# Altium schematic sheet NG2094_IR_Flood.SchDoc(NG2094_IR_Flood)
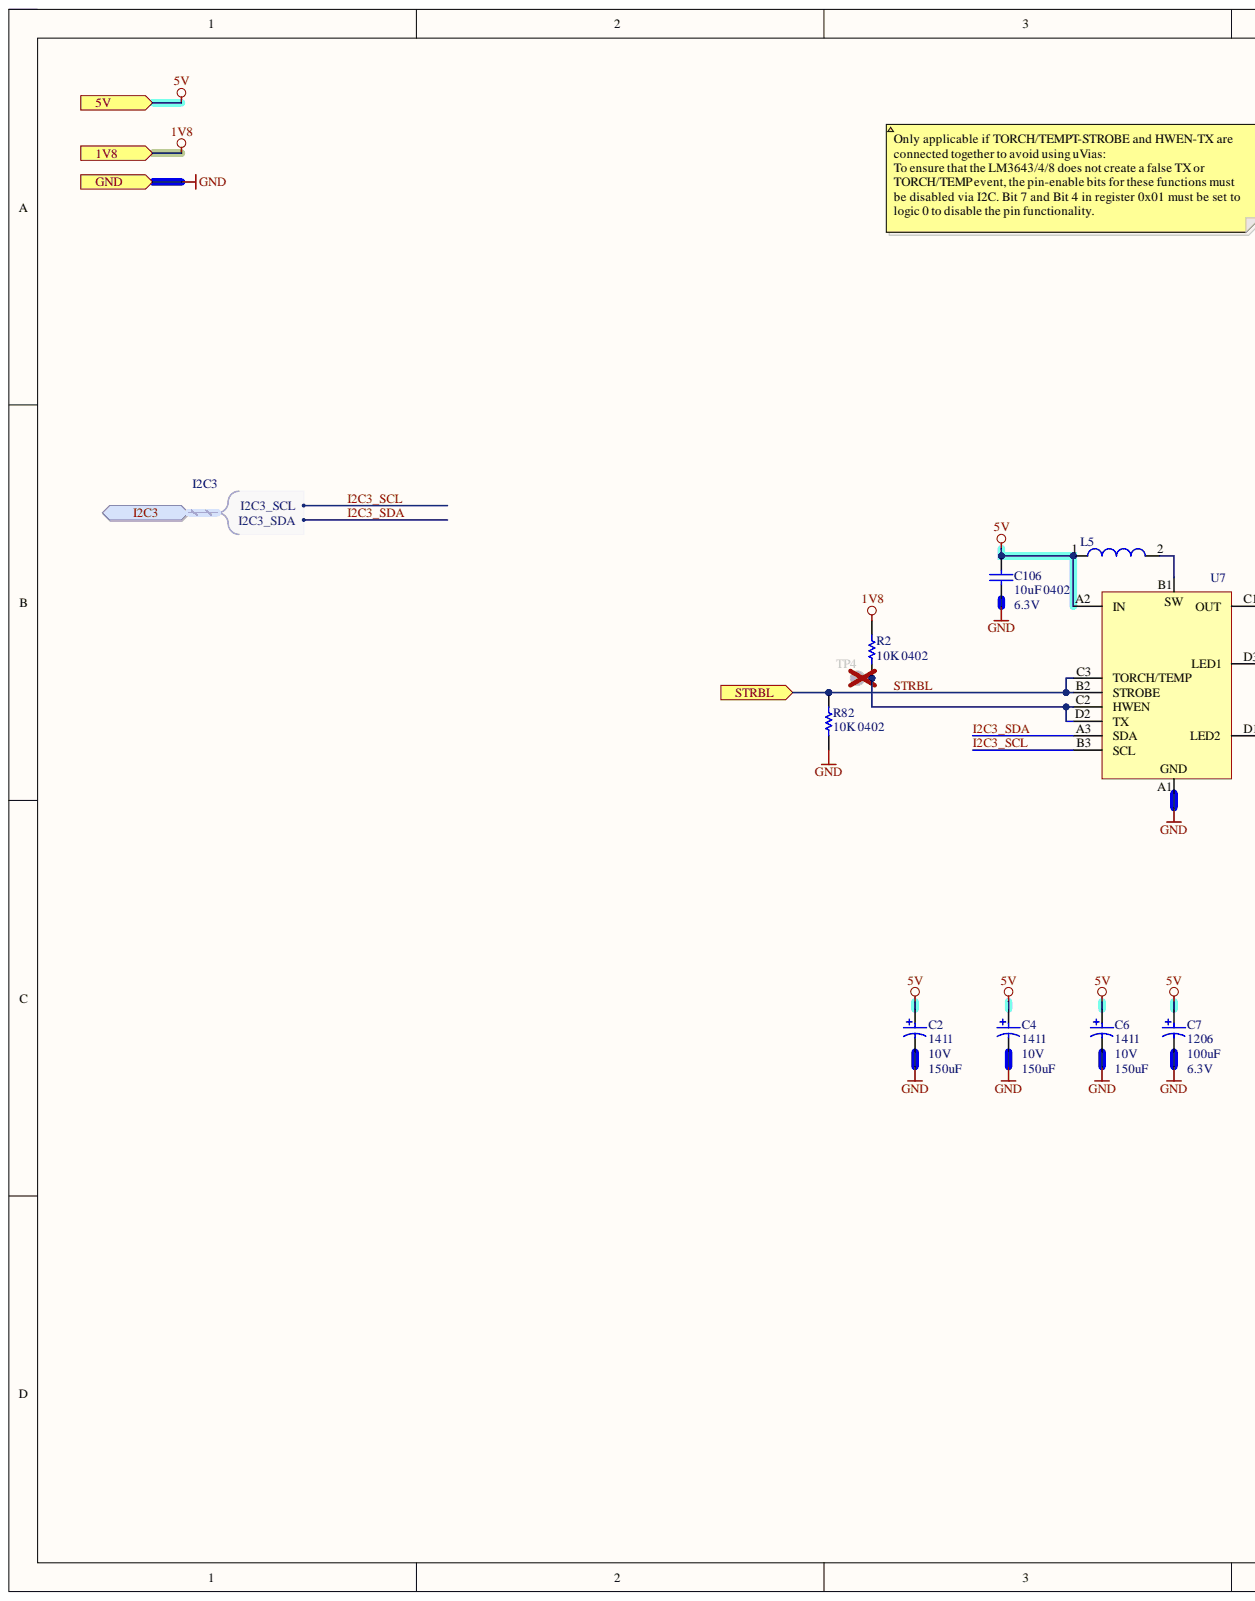
[diagram p0: NG2094_IR_Flood.SchDoc, left]
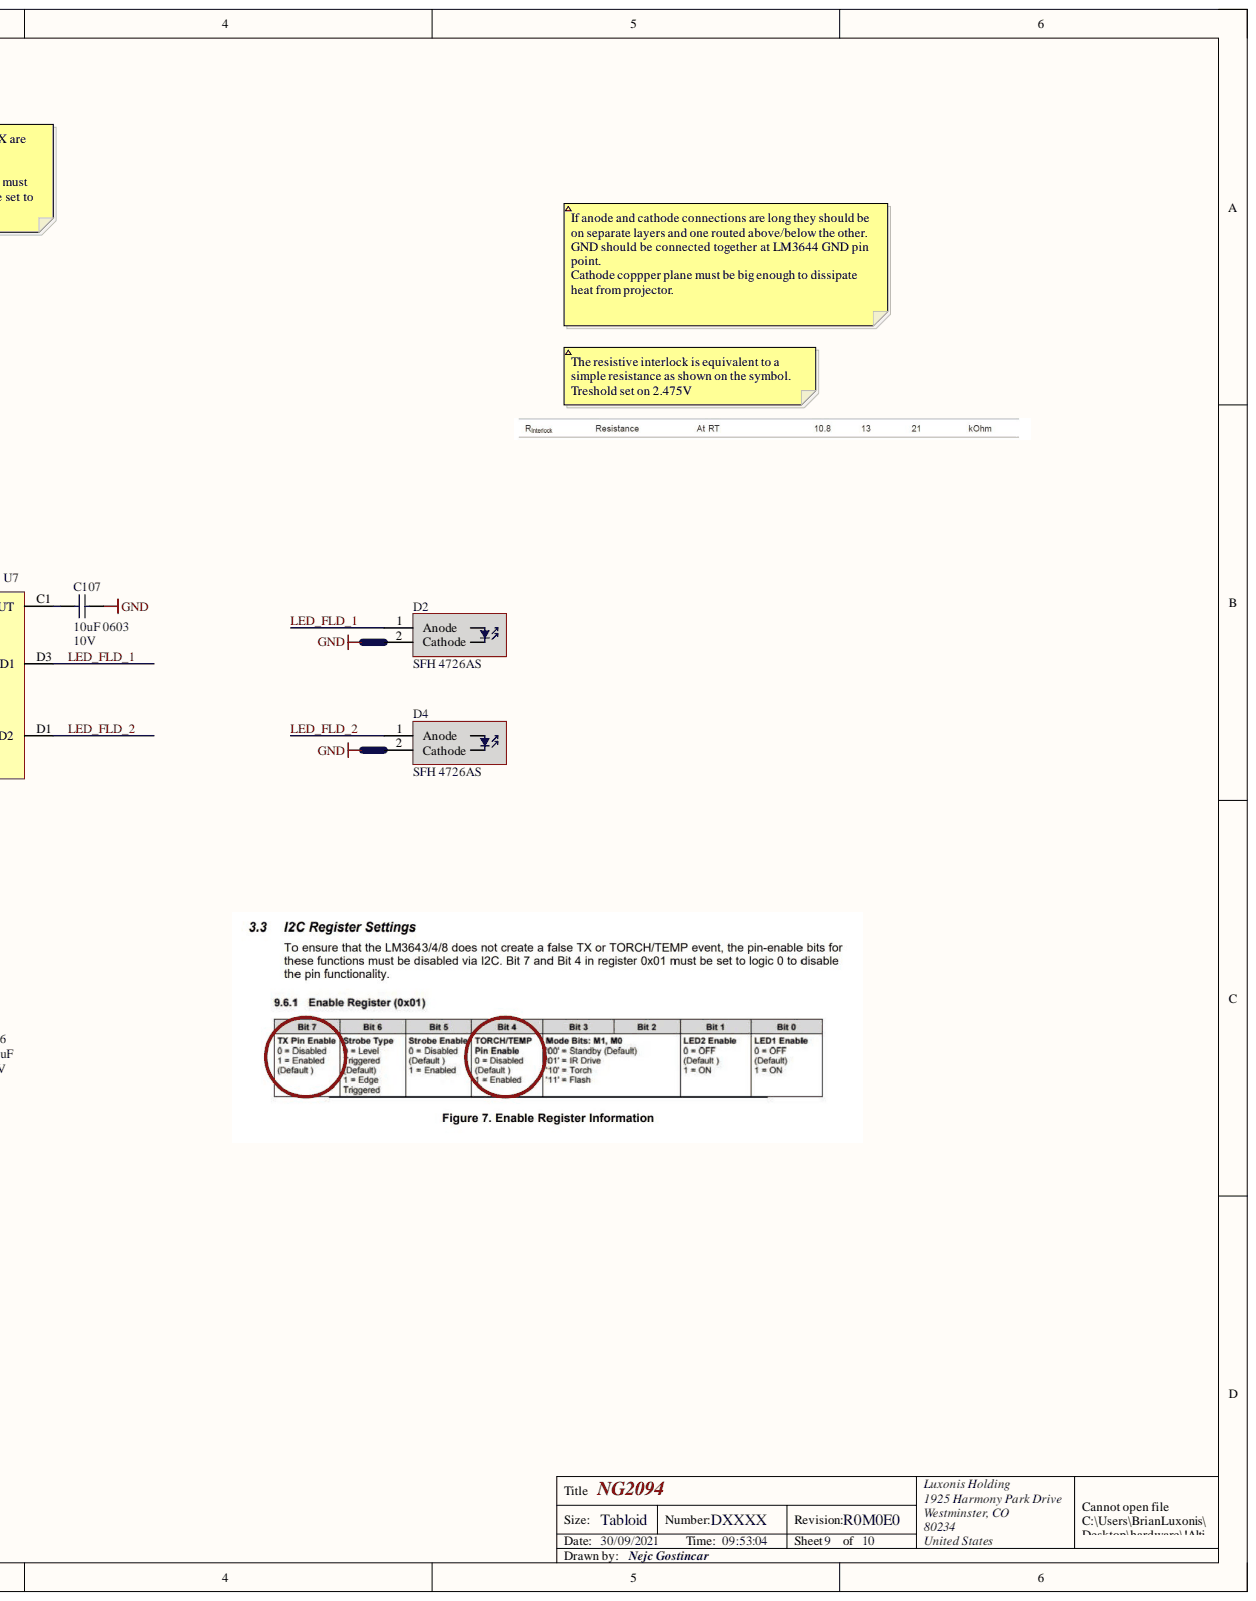
[diagram p1: NG2094_IR_Flood.SchDoc, right]

## Parts
C2  Capacitance: 150uF  Rated DC Voltage (URdc): 10V  Size Code: 1411  [RESTL1210(3225)_N]  (hidden comment: 150uF 1206 10V tantal)
C4  Capacitance: 150uF  Rated DC Voltage (URdc): 10V  Size Code: 1411  [RESTL1210(3225)_N]  (hidden comment: 150uF 1206 10V tantal)
C6  Capacitance: 150uF  Rated DC Voltage (URdc): 10V  Size Code: 1411  [RESTL1210(3225)_N]  (hidden comment: 150uF 1206 10V tantal)
C7  Capacitance: 100uF  Rated DC Voltage (URdc): 6.3V  Size Code: 1206  [RESTL1206(3216)_L]  (hidden comment: 100uF 1206 6V3 tantal)
C106  10uF 0402  Capacitance: 10uF  Voltage Rating: 6.3V  [CAPC0402(1005)70_L]
C107  10uF 0603  Capacitance: 10uF  Case Code (Imperial): 0603  Voltage Rating: 10V  [CAPC0603(1608)100_L]
D2  SFH 4726AS  [SFH 4726AS]
D4  SFH 4726AS  [SFH 4726AS]
L5  1uH, 3.2A, 20%  [DFE252012P-1R0M=P2]  (value not drawn)
R2  10K 0402  Case Code (Imperial): 0402  [RESC0402(1005)_L]
R82  10K 0402  Case Code (Imperial): 0402  [RESC0402(1005)_L]
TP4  TP100_200_NS  [TP100_200_NS]  (value not drawn)  (no BOM)
U7  LM3644YFFR  [LM3644YFFR]  (value not drawn)

## Ports
1V8, 5V, GND, I2C3, I2C3.I2C3_SCL, I2C3.I2C3_SDA, STRBL

## Nets
1V8: R2.2 ; port 1V8
5V: C2.1 C4.1 C6.1 C7.1 C106.2 L5.1 U7.A2(IN) ; port 5V
GND: C2.2 C4.2 C6.2 C7.2 C106.1 C107.2 D2.2 D4.2 R82.1 U7.A1 ; port GND
I2C3_SCL: U7.B3(SCL) ; port I2C3
I2C3_SDA: U7.A3(SDA) ; port I2C3
LED_FLD_1: D2.1 U7.D3(LED1)
LED_FLD_2: D4.1 U7.D1(LED2)
STRBL: R82.2 U7.B2(STROBE) U7.C3(TORCH/TEMP) ; port STRBL
NetC107_1: C107.1 U7.C1(OUT)
NetL5_2: L5.2 U7.B1(SW)
NetR2_1: R2.1 TP4.1 U7.C2(HWEN) U7.D2(TX)

## Library data of the parts
C2 C4 C6 = TLJB157M010R0500: Tantalum Capacitor, Polarized, Tantalum (dry/solid), 10V, 20% +Tol, 20% -Tol, 150uF, Surface Mount, 1210; Manufacturer 1: Kyocera AVX; ESR (Equivalent Series Resistance): 500mR; Leakage Current: 15uA; Ripple Current: 371mA; Capacitor Type: Tantalum; Category: Tantalum Capacitors; Component Kind: Standard; ComponentLink1Description: https://datasheet.ciiva.com:443/pdfs/VipMasterIC/IC/AVXC/AVXC-S-A0008508048/AVXC-S-A0008508048-1.pdf?src-supplier=IHS+Markit; ComponentLink1URL: https://datasheet.ciiva.com:443/pdfs/VipMasterIC/IC/AVXC/AVXC-S-A0008508048/AVXC-S-A0008508048-1.pdf?src-supplier=IHS+Markit; ComponentLink2Description: https://datasheet.ciiva.com/264/tlj-264786.pdf?src-supplier=Digi-Key; ComponentLink2URL: https://datasheet.ciiva.com/264/tlj-264786.pdf?src-supplier=Digi-Key; ComponentLink3Description: https://datasheet.ciiva.com/264/tlj-264787.pdf?src-supplier=Digi-Key; ComponentLink3URL: https://datasheet.ciiva.com/264/tlj-264787.pdf?src-supplier=Digi-Key; ComponentLink4Description: https://datasheet.ciiva.com/264/tlj-264788.pdf?src-supplier=Digi-Key; ComponentLink4URL: https://datasheet.ciiva.com/264/tlj-264788.pdf?src-supplier=Digi-Key; ComponentLink5Description: https://datasheet.ciiva.com/46385/1910903-46385237.pdf?src-supplier=Element14; ComponentLink5URL: https://datasheet.ciiva.com/46385/1910903-46385237.pdf?src-supplier=Element14; ComponentLink6Description: https://datasheet.ciiva.com/28515/tlj-28515528.pdf?src-supplier=Element14; ComponentLink6URL: https://datasheet.ciiva.com/28515/tlj-28515528.pdf?src-supplier=Element14; ComponentLink7Description: https://datasheet.ciiva.com/7773/1533257-7773833.pdf?src-supplier=Element14; ComponentLink7URL: https://datasheet.ciiva.com/7773/1533257-7773833.pdf?src-supplier=Element14; ComponentLink8Description: https://datasheet.ciiva.com/14593/70001364-14593673.pdf?src-supplier=Allied+Electronics; ComponentLink8URL: https://datasheet.ciiva.com/14593/70001364-14593673.pdf?src-supplier=Allied+Electronics; ComponentLink9Description: https://datasheet.ciiva.com/14606/70001364-14606409.pdf?src-supplier=Allied+Electronics; ComponentLink9URL: https://datasheet.ciiva.com/14606/70001364-14606409.pdf?src-supplier=Allied+Electronics; ComponentLink10Description: https://datasheet.ciiva.com/7773/1533257-7773834.pdf?src-supplier=Element14; ComponentLink10URL: https://datasheet.ciiva.com/7773/1533257-7773834.pdf?src-supplier=Element14; ComponentLink11Description: https://datasheet.ciiva.com/11229/tlj-11229389.pdf?src-supplier=Avnet; ComponentLink11URL: https://datasheet.ciiva.com/11229/tlj-11229389.pdf?src-supplier=Avnet; ComponentLink12Description: https://datasheet.ciiva.com/17339/tlj-17339422.pdf?src-supplier=Avnet+Europe; ComponentLink12URL: https://datasheet.ciiva.com/17339/tlj-17339422.pdf?src-supplier=Avnet+Europe; Dielectric Material: Tantalum; Height: 1.9mm; JESD-609 Code: e3; Length: 3.5mm; Library Name: NG2094.SchLib; Manufacturer Part Number 1: TLJB157M010R0500; Max Operating Temperature: 125°C; Min Operating Temperature: -55°C; Mount: Surface Mount; Negative Tolerance: 20%; Number of Pins: 2; Package Shape: Rectangular; Package Style: SMTMeter; Packaging: Tape and Reel; Polarity: Polarized; Positive Tolerance: 20%; Pricing 1: 1=0,74000, 10=0,54700, 50=0,43800, 100=0,37540, 500=0,29716, 1000=0,25024 (USD); REACH SVHC: Yes; RoHS: Yes; RoHS Compliant: Yes; Stock 1: 10000; Supplier 1: Digi-Key; Supplier Part Number 1: 478-3349-1-ND; Terminal Finish: Nickel; Termination: J BEND; Width: 2.8mm
C7 = TLJA107M006R0500: Tantalum Capacitor, Polarized, Tantalum (dry/solid), 6.3V, 20% +Tol, 20% -Tol, 100uF, Surface Mount, 1206; Manufacturer 1: Kyocera AVX; ESR (Equivalent Series Resistance): 500mR; Leakage Current: 6uA; Ripple Current: 349mA; Capacitor Type: Tantalum; Category: Tantalum Capacitors; Component Kind: Standard; ComponentLink1Description: https://datasheet.ciiva.com:443/pdfs/VipMasterIC/IC/AVXC/AVXC-S-A0008508048/AVXC-S-A0008508048-1.pdf?src-supplier=IHS+Markit; ComponentLink1URL: https://datasheet.ciiva.com:443/pdfs/VipMasterIC/IC/AVXC/AVXC-S-A0008508048/AVXC-S-A0008508048-1.pdf?src-supplier=IHS+Markit; ComponentLink2Description: https://datasheet.ciiva.com/3797/21tlj-3797551.pdf?src-supplier=Arrow+Electronics; ComponentLink2URL: https://datasheet.ciiva.com/3797/21tlj-3797551.pdf?src-supplier=Arrow+Electronics; ComponentLink3Description: https://datasheet.ciiva.com/7720/1533257-7720677.pdf?src-supplier=Element14; ComponentLink3URL: https://datasheet.ciiva.com/7720/1533257-7720677.pdf?src-supplier=Element14; ComponentLink4Description: https://datasheet.ciiva.com/28609/packmark-28609461.pdf?src-supplier=Element14; ComponentLink4URL: https://datasheet.ciiva.com/28609/packmark-28609461.pdf?src-supplier=Element14; ComponentLink5Description: https://datasheet.ciiva.com/264/tlj-264694.pdf?src-supplier=Digi-Key; ComponentLink5URL: https://datasheet.ciiva.com/264/tlj-264694.pdf?src-supplier=Digi-Key; ComponentLink6Description: https://datasheet.ciiva.com/264/tlj-264695.pdf?src-supplier=Digi-Key; ComponentLink6URL: https://datasheet.ciiva.com/264/tlj-264695.pdf?src-supplier=Digi-Key; ComponentLink7Description: https://datasheet.ciiva.com/264/tlj-264696.pdf?src-supplier=Digi-Key; ComponentLink7URL: https://datasheet.ciiva.com/264/tlj-264696.pdf?src-supplier=Digi-Key; ComponentLink8Description: https://datasheet.ciiva.com/11229/tlj-11229385.pdf?src-supplier=Avnet; ComponentLink8URL: https://datasheet.ciiva.com/11229/tlj-11229385.pdf?src-supplier=Avnet; ComponentLink9Description: https://datasheet.ciiva.com/28609/1910903-28609449.pdf?src-supplier=Element14; ComponentLink9URL: https://datasheet.ciiva.com/28609/1910903-28609449.pdf?src-supplier=Element14; ComponentLink10Description: https://datasheet.ciiva.com/16501/tlj-16501341.pdf?src-supplier=Future+Electronics; ComponentLink10URL: https://datasheet.ciiva.com/16501/tlj-16501341.pdf?src-supplier=Future+Electronics; ComponentLink11Description: https://datasheet.ciiva.com/14590/70001364-14590207.pdf?src-supplier=Allied+Electronics; ComponentLink11URL: https://datasheet.ciiva.com/14590/70001364-14590207.pdf?src-supplier=Allied+Electronics; Dielectric Material: Tantalum; Height: 1.6mm; JESD-609 Code: e3; Length: 3.2mm; Library Name: NG2094.SchLib; Manufacturer Part Number 1: TLJA107M006R0500; Max Operating Temperature: 125°C; Min Operating Temperature: -55°C; Mount: Surface Mount; Negative Tolerance: 20%; Number of Pins: 2; Package Shape: Rectangular; Package Style: SMTMeter; Packaging: Tape and Reel; Polarity: Polarized; Positive Tolerance: 20%; Pricing 1: 1=0,68000, 10=0,49800, 50=0,39840, 100=0,34150, 500=0,27036, 1000=0,22768 (USD); REACH SVHC: Yes; RoHS: Yes; RoHS Compliant: Yes; Stock 1: 1699; Supplier 1: Digi-Key; Supplier Part Number 1: 478-3345-1-ND; Terminal Finish: Nickel; Termination: J BEND; Width: 1.6mm
C106 = GRM155R60J106ME15D: CAP CER 10UF 6.3V X5R 0402 20%; Manufacturer 1: Murata; Case Code (Imperial): 0402; Case Code (Metric): 1005; Case/Package: 0402; Component Kind: Standard; ComponentLink1Description: https://www.murata.com/~/media/webrenewal/support/library/catalog/products/capacitor/mlcc/c02e.ashx?la=en-us&src-supplier=Digi-Key; ComponentLink1URL: https://www.murata.com/~/media/webrenewal/support/library/catalog/products/capacitor/mlcc/c02e.ashx?la=en-us&src-supplier=Digi-Key; Dielectric: X5R; Length: 0.039inch; Manufacturer Part Number 1: GRM155R60J106ME15D; Max Operating Temperature: 85°C; Min Operating Temperature: -55°C; Mount: Surface Mount; Packaging: Tape and Reel; Pricing 1: 1=0.23000, 10=0.15700, 100=0.07950, 500=0.05442, 1000=0.04814, 2500=0.04605, 5000=0.04186 (USD); RoHS: Yes; Stock 1: 1839627; Supplier 1: Digi-Key; Supplier Part Number 1: 490-13238-1-ND; Thickness: 0.026inch; Tolerance: 20%; Voltage Rating (DC): 6.3V; Width: 0.02inch; Library Name: LuxonisMaster.SchLib
C107 = GRM188R61A106ME69D: CAP CER 10UF 10V X5R 0603 20%; Manufacturer 1: Murata; Material: Ceramic; Case Code (Metric): 1608; Case/Package: 0603; Component Kind: Standard; ComponentLink1Description: http://www.mouser.com/ds/2/281/c02e-2905.pdf?src-supplier=Mouser; ComponentLink1URL: http://www.mouser.com/ds/2/281/c02e-2905.pdf?src-supplier=Mouser; ComponentLink2Description: http://components.arrow.com/part/dataview/0323_06119472?src-supplier=Arrow+Europe; ComponentLink2URL: http://components.arrow.com/part/dataview/0323_06119472?src-supplier=Arrow+Europe; ComponentLink3Description: http://www.farnell.com/datasheets/2048014.pdf?src-supplier=Farnell; ComponentLink3URL: http://www.farnell.com/datasheets/2048014.pdf?src-supplier=Farnell; ComponentLink4Description: http://www.farnell.com/datasheets/1872210.pdf?src-supplier=Farnell; ComponentLink4URL: http://www.farnell.com/datasheets/1872210.pdf?src-supplier=Farnell; ComponentLink5Description: http://search.murata.co.jp/Ceramy/image/img/A01X/G101/ENG/GRM188R61A106ME69-01.pdf?src-supplier=Digi-Key; ComponentLink5URL: http://search.murata.co.jp/Ceramy/image/img/A01X/G101/ENG/GRM188R61A106ME69-01.pdf?src-supplier=Digi-Key; ComponentLink6Description: http://www.farnell.com/datasheets/1872210.pdf?src-supplier=Newark; ComponentLink6URL: http://www.farnell.com/datasheets/1872210.pdf?src-supplier=Newark; ComponentLink7Description: http://www.farnell.com/datasheets/2048014.pdf?src-supplier=Element14; ComponentLink7URL: http://www.farnell.com/datasheets/2048014.pdf?src-supplier=Element14; ComponentLink8Description: http://www.farnell.com/datasheets/2048014.pdf?src-supplier=Newark; ComponentLink8URL: http://www.farnell.com/datasheets/2048014.pdf?src-supplier=Newark; Depth: 0.8mm; Dielectric: X5R; Height: 0.8mm; Lead Free: Lead Free; Length: 1.6mm; Manufacturer Part Number 1: GRM188R61A106ME69D; Max Operating Temperature: 85°C; Min Operating Temperature: -55°C; Mount: Surface Mount; Package Quantity: 4000; Packaging: Tape and Reel; Pricing 1: 1=0.21000, 10=0.14100, 100=0.07130, 500=0.04876, 1000=0.04313 (USD); REACH SVHC: Unknown; RoHS: Yes; Stock 1: 2873098; Supplier 1: Digi-Key; Supplier Part Number 1: 490-10475-1-ND; Termination: SMD/SMT; Thickness: 0.035inch; Tolerance: 20%; Voltage Rating (DC): 10V; Weight: 0.000222oz; Width: 0.8mm; Library Name: LuxonisMaster.SchLib
D2 D4 = SFH 4726AS: OSLON Black Series (940 nm) - 150°; Manufacturer 1: Osram Opto; Manufacturer Part Number 1: SFH 4726AS A01; Optoelectronic Device Type: INFRARED LED; Category: LEDs; Component Kind: Standard; ComponentLink1Description: https://datasheet.ciiva.com:443/pdfs/VipMasterIC/IC/OSOS/OSOS-S-A0009010498/OSOS-S-A0009010498-1.pdf?src-supplier=IHS+Markit; ComponentLink1URL: https://datasheet.ciiva.com:443/pdfs/VipMasterIC/IC/OSOS/OSOS-S-A0009010498/OSOS-S-A0009010498-1.pdf?src-supplier=IHS+Markit; ComponentLink2Description: http://www.farnell.com/datasheets/2711624.pdf?src-supplier=Farnell; ComponentLink2URL: http://www.farnell.com/datasheets/2711624.pdf?src-supplier=Farnell; Library Name: NG2094.SchLib; Pricing 1: 1=4,49000, 10=3,74000, 25=3,35000, 50=2,97000, 100=2,58000 (USD); REACH SVHC: Yes; RoHS: Yes; RoHS Compliant: Yes; Stock 1: 628; Supplier 1: Newark; Supplier Part Number 1: 94AC5058
L5 = DFE252012P-1R0M=P2: FIXED IND 1UH 3.2A 42 MOHM SMD; Manufacturer: Murata; Core Material: Ferrite; Current Rating: 4.3A; DC Resistance (DCR): 42mR; Shielding: Shielded; Test Frequency: 1MHz; Case Code (Imperial): 1008; Case Code (Metric): 2520; Case/Package: 1008; Component Kind: Standard; ComponentLink1Description: http://www.farnell.com/datasheets/2550134.pdf?src-supplier=Farnell; ComponentLink1URL: http://www.farnell.com/datasheets/2550134.pdf?src-supplier=Farnell; ComponentLink2Description: https://www.murata.com/~/media/webrenewal/products/inductor/chip/tokoproducts/wirewoundmetalalloychiptype/m_dfe252012p.ashx?src-supplier=Digi-Key; ComponentLink2URL: https://www.murata.com/~/media/webrenewal/products/inductor/chip/tokoproducts/wirewoundmetalalloychiptype/m_dfe252012p.ashx?src-supplier=Digi-Key; ComponentLink3Description: http://www.murata.com/~/media/webrenewal/products/inductor/chip/tokoproducts/wirewoundmetalalloychiptype/m_dfe252012p.ashx?src-supplier=Digi-Key; ComponentLink3URL: http://www.murata.com/~/media/webrenewal/products/inductor/chip/tokoproducts/wirewoundmetalalloychiptype/m_dfe252012p.ashx?src-supplier=Digi-Key; ComponentLink4Description: http://www.toko.co.jp/products/pdf/inductors/dfe252012p.pdf?src-supplier=Avnet; ComponentLink4URL: http://www.toko.co.jp/products/pdf/inductors/dfe252012p.pdf?src-supplier=Avnet; Composition: Wirewound; Depth: 2mm; Height - Seated (Max): 0.039inch; Inductance: 1uH; Length: 0.098inch; Manufacturer 1: Murata; Manufacturer 2: Murata; Manufacturer Part Number 1: DFE252012P-1R0M=P2; Manufacturer Part Number 2: DFE252012P-1R0M=P2; Max DC Current: 3.2A; Max Operating Temperature: 125°C; Min Operating Temperature: -40°C; Mount: Surface Mount; Packaging: Tape and Reel; Pricing 1: 1=0.43000, 10=0.37800, 100=0.27030, 500=0.22702, 1000=0.18377 (USD); Pricing 2: 1=0.43000, 10=0.37800, 100=0.27030, 500=0.22702, 1000=0.18377 (USD); Pricing 3: 1=0.43000, 10=0.37900, 50=0.37900, 100=0.27000, 1000=0.18400, 10000=0.14600 (USD); Pricing 4: 1=0.64000, 10=0.56500, 100=0.40500, 500=0.34000, 1000=0.27500 (SGD); RoHS: Yes; Stock 1: 2560; Stock 2: 95; Stock 3: 667; Stock 4: 41; Supplier 1: Digi-Key; Supplier 2: Digi-Key; Supplier 3: Mouser; Supplier 4: Element14; Supplier Part Number 1: 490-10647-1-ND; Supplier Part Number 2: 490-10647-6-ND; Supplier Part Number 3: 81-DFE252012P-1R0MP2; Supplier Part Number 4: 2530071; Tolerance: 20%; Width: 0.079inch; Library Name: LuxonisMaster.SchLib
R2 R82 = RC0402FR-1310KL: RES SMD 10K OHM 1% 0402 1/16W Chip Resistor, 0402 [1005 Metric], 10 kohm, RC Series, 50 V, Thick Film, 62.5 mW; Manufacturer 1: Yageo; Power Rating: 62.5mW; Resistance: 10kR; Temperature Coefficient: 100ppm/°C; Voltage Rating: 50V; Case Code (Metric): 1005; Case/Package: 0402; Component Kind: Standard; ComponentLink1Description: https://datasheet.ciiva.com/16940/pyu-rc0402-51-rohs-l-6-16940293.pdf?src-supplier=Avnet+Europe; ComponentLink1URL: https://datasheet.ciiva.com/16940/pyu-rc0402-51-rohs-l-6-16940293.pdf?src-supplier=Avnet+Europe; ComponentLink2Description: https://datasheet.ciiva.com/28386/pyu-rc0402-51-rohs-l-6-28386855.pdf?src-supplier=Avnet+Europe; ComponentLink2URL: https://datasheet.ciiva.com/28386/pyu-rc0402-51-rohs-l-6-28386855.pdf?src-supplier=Avnet+Europe; ComponentLink3Description: https://datasheet.ciiva.com/16740/pyu-rc0402-51-rohs-l-6-r-16740602.pdf?src-supplier=Future+Electronics; ComponentLink3URL: https://datasheet.ciiva.com/16740/pyu-rc0402-51-rohs-l-6-r-16740602.pdf?src-supplier=Future+Electronics; ComponentLink4Description: https://datasheet.ciiva.com/8891/pyu-rc0402-51-rohs-l-6-8891339.pdf?src-supplier=Avnet; ComponentLink4URL: https://datasheet.ciiva.com/8891/pyu-rc0402-51-rohs-l-6-8891339.pdf?src-supplier=Avnet; ComponentLink5Description: https://datasheet.ciiva.com/5557/pyu-rc0402-51-rohs-l-6-r-5557997.pdf?src-supplier=Mouser; ComponentLink5URL: https://datasheet.ciiva.com/5557/pyu-rc0402-51-rohs-l-6-r-5557997.pdf?src-supplier=Mouser; ComponentLink6Description: https://datasheet.ciiva.com/7983/1018594-7983533.pdf?src-supplier=Element14; ComponentLink6URL: https://datasheet.ciiva.com/7983/1018594-7983533.pdf?src-supplier=Element14; Composition: Thick Film; Contact Plating: Tin,Matte,Lead; Depth: 0.5mm; Lead Free: Lead Free; Length: 1mm; Manufacturer Part Number 1: RC0402FR-1310KL; Max Operating Temperature: 155°C; Military Standard: Not; Min Operating Temperature: -55°C; Number of Pins: 2; Number of Terminations: 2; Packaging: Tape and Reel; Pricing 1: 1=0.10000, 25=0.01200, 100=0.00400, 250=0.00400, 500=0.00300, 1000=0.00300 (USD); Radiation Hardening: No; REACH SVHC: No SVHC; RoHS: Yes; Stock 1: 62239; Supplier 1: Newark; Supplier 2: Future Electronics; Supplier 3: Mouser; Supplier 4: Element14; Supplier Part Number 1: 67R9960; Supplier Part Number 2: RC0402FR-1310KL-CUTT; Supplier Part Number 3: 603-RC0402FR-1310KL; Supplier Part Number 4: 1799235; Termination: SMD/SMT; Tolerance: 1%; Voltage Rating (DC): 50V; Working Voltage: 50V; Library Name: LuxonisMaster.SchLib
TP4 = TEST_POINT: Library Name: LuxonisMaster.SchLib
U7 = LM3644: LED Driver, 2-Segment, PBGA12; Manufacturer 1: Texas Instruments; Data Input Mode: SERIAL; Interface IC Type: LED DISPLAY DRIVER; Max Supply Voltage: 5.5V; Min Supply Voltage: 2.5V; Multiplexed Display Capability: No; Number of Functions: 1; Number of Segments: 2; Package Body Material: Plastic; Supply Voltage-Nom: 3.6V; Category: LED Drivers; Component Kind: Standard; ComponentLink1Description: https://www.ti.com:443/lit/ds/symlink/lm3644.pdf?src-supplier=IHS+Markit; ComponentLink1URL: https://www.ti.com:443/lit/ds/symlink/lm3644.pdf?src-supplier=IHS+Markit; ComponentLink2Description: https://datasheet.ciiva.com/48475/lm3644-48475318.pdf?src-supplier=Avnet; ComponentLink2URL: https://datasheet.ciiva.com/48475/lm3644-48475318.pdf?src-supplier=Avnet; Height - Seated (Max): 0.625mm; JESD-609 Code: e1; Length: 1.66mm; Library Name: NG2094.SchLib; Manufacturer Part Number 1: LM3644YFFR; Max Operating Temperature: 85°C; Min Operating Temperature: -40°C; Moisture Sensitivity Level: 1; Number of Pins: 12; Package Code: VFBGA; Package Shape: Rectangular; Package Style: GRID ARRAY, VERY THIN PROFILE, FINE PITCHMeter; Peak Reflow Temperature (Cel): 260°C; Pricing 1: 1=0,64580, 10=0,63600, 25=0,62590, 100=0,61590, 250=0,60610, 500=0,59600, 1000=0,58600, 3000=0,35000, 30000=0,34520, 300000=0,31610, 1500000=0,29870, 3000000=0,29850 (USD); REACH SVHC: Yes; RoHS: Yes; RoHS Compliant: Yes; Stock 1: 2033; Supplier 1: Arrow Electronics; Supplier Part Number 1: LM3644YFFR; Surface Mount: Yes; Temperature Grade: INDUSTRIAL; Terminal Finish: Copper; Terminal Form: Ball; Terminal Pitch: 0.4mm; Terminal Position: BOTTOM; Width: 1.28mm
